# Altium schematic sheet LIDO-HW.100.FrontConnector.SchDoc(LIDO-HW.100.FrontConnector)
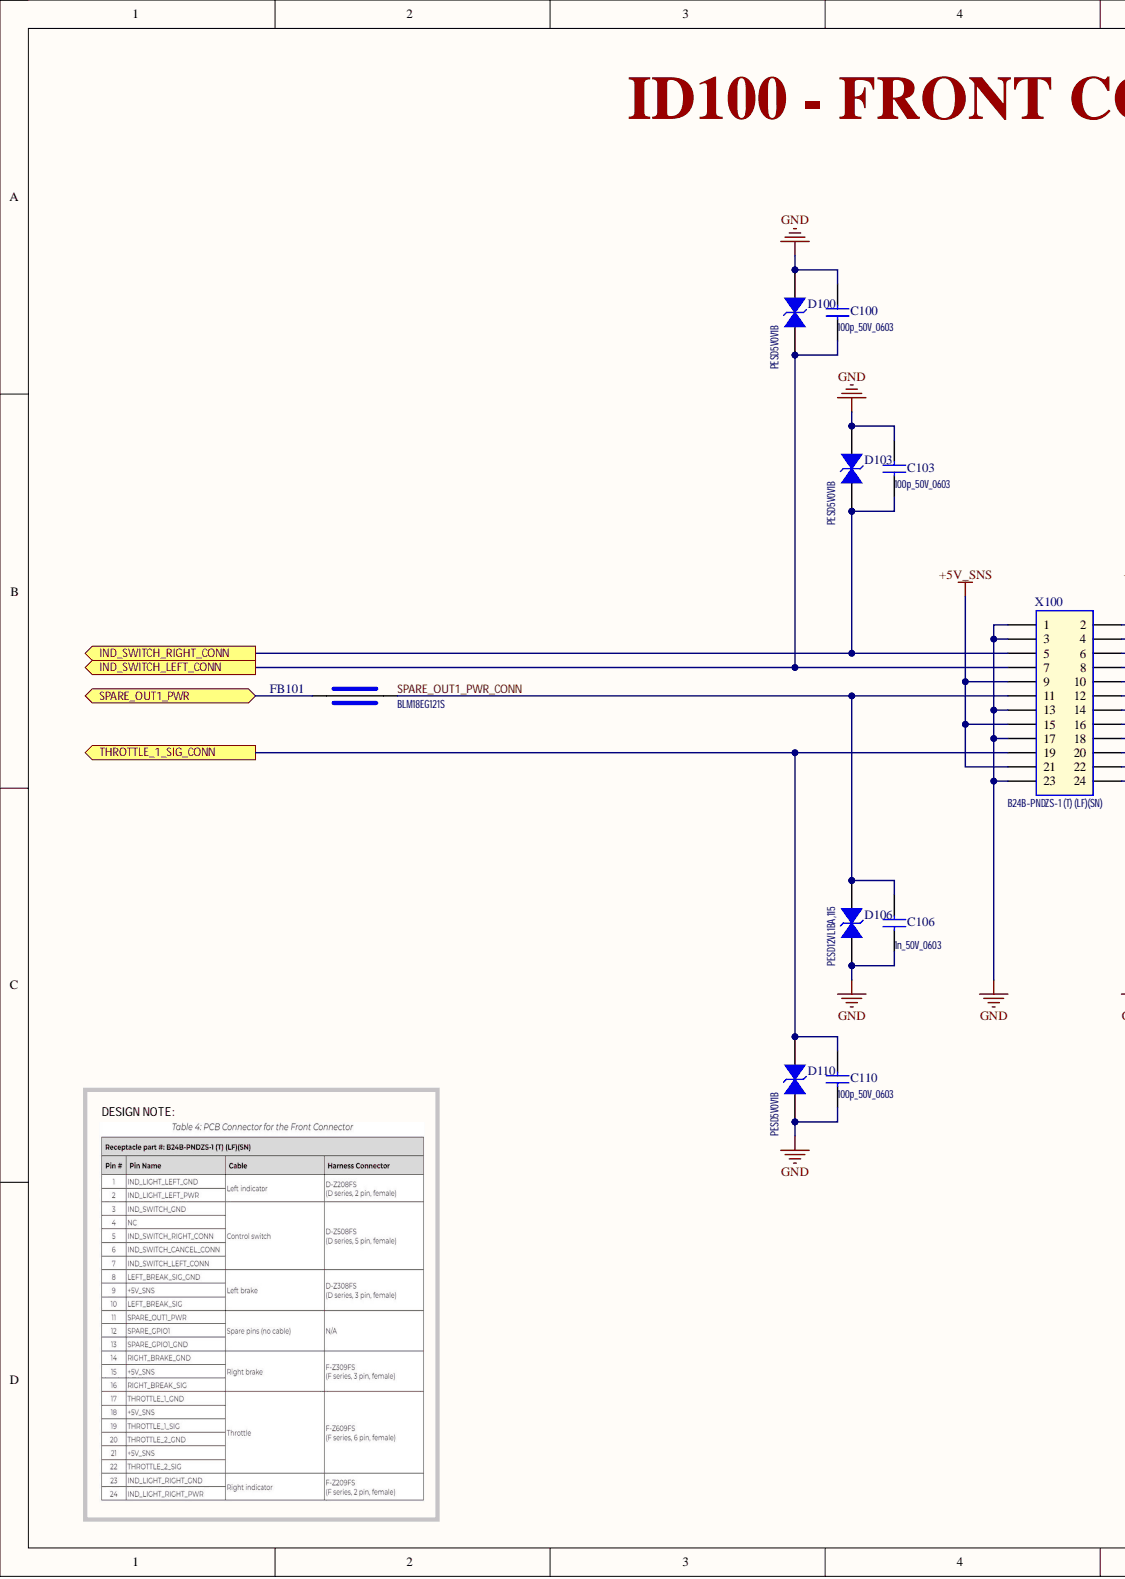
[diagram p0: LIDO-HW.100.FrontConnector.SchDoc, left]
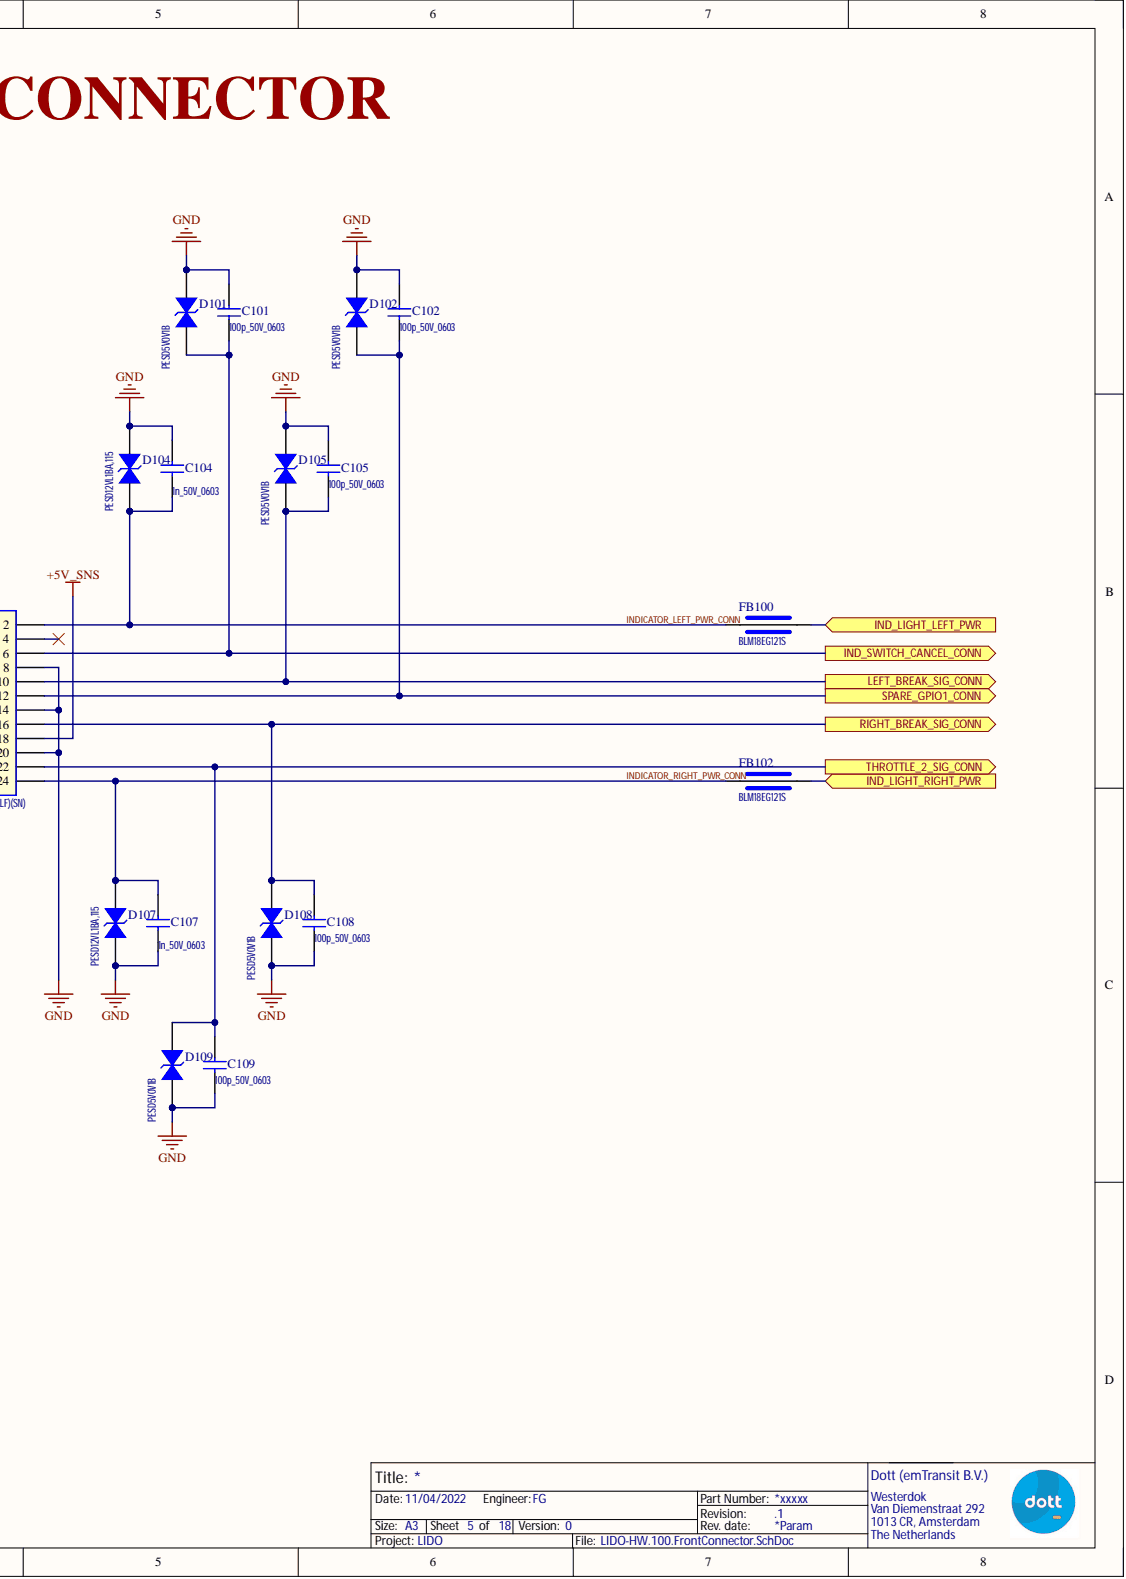
[diagram p1: LIDO-HW.100.FrontConnector.SchDoc, right]

## Parts
C100  100p_50V_0603
C101  100p_50V_0603
C102  100p_50V_0603
C103  100p_50V_0603
C104  1n_50V_0603
C105  100p_50V_0603
C106  1n_50V_0603
C107  1n_50V_0603
C108  100p_50V_0603
C109  100p_50V_0603
C110  100p_50V_0603
D100  PESD5V0V1B
D101  PESD5V0V1B
D102  PESD5V0V1B
D103  PESD5V0V1B
D104  PESD12VL1BA,115
D105  PESD5V0V1B
D106  PESD12VL1BA,115
D107  PESD12VL1BA,115
D108  PESD5V0V1B
D109  PESD5V0V1B
D110  PESD5V0V1B
FB100  BLM18EG121S
FB101  BLM18EG121S
FB102  BLM18EG121S
X100  B24B-PNDZS-1 (T) (LF)(SN)

## Ports
IND_LIGHT_LEFT_PWR, IND_LIGHT_RIGHT_PWR, IND_SWITCH_CANCEL_CONN, IND_SWITCH_LEFT_CONN, IND_SWITCH_RIGHT_CONN, LEFT_BREAK_SIG_CONN, RIGHT_BREAK_SIG_CONN, SPARE_GPIO1_CONN, SPARE_OUT1_PWR, THROTTLE_1_SIG_CONN, THROTTLE_2_SIG_CONN

## Nets
+5V_SNS: X100.9 X100.15 X100.18 X100.21
GND: C100.2 C101.2 C102.2 C103.2 C104.2 C105.2 C106.1 C107.1 C108.1 C109.1 C110.1 D100.C D101.C D102.C D103.C D104.C D105.C D106.A D107.A D108.A D109.A D110.A X100.1 X100.3 X100.8 X100.13 X100.14 X100.17 X100.20 X100.23
IND_LIGHT_LEFT_PWR: FB100.1 ; port IND_LIGHT_LEFT_PWR
IND_LIGHT_RIGHT_PWR: FB102.1 ; port IND_LIGHT_RIGHT_PWR
IND_SWITCH_CANCEL_CONN: C101.1 D101.A X100.6 ; port IND_SWITCH_CANCEL_CONN
IND_SWITCH_LEFT_CONN: C100.1 D100.A X100.7 ; port IND_SWITCH_LEFT_CONN
IND_SWITCH_RIGHT_CONN: C103.1 D103.A X100.5 ; port IND_SWITCH_RIGHT_CONN
INDICATOR_LEFT_PWR_CONN: C104.1 D104.A FB100.2 X100.2
INDICATOR_RIGHT_PWR_CONN: C107.2 D107.C FB102.2 X100.24
LEFT_BREAK_SIG_CONN: C105.1 D105.A X100.10 ; port LEFT_BREAK_SIG_CONN
RIGHT_BREAK_SIG_CONN: C108.2 D108.C X100.16 ; port RIGHT_BREAK_SIG_CONN
SPARE_GPIO1_CONN: C102.1 D102.A X100.12 ; port SPARE_GPIO1_CONN
SPARE_OUT1_PWR: FB101.1 ; port SPARE_OUT1_PWR
SPARE_OUT1_PWR_CONN: C106.2 D106.C FB101.2 X100.11
THROTTLE_1_SIG_CONN: C110.2 D110.C X100.19 ; port THROTTLE_1_SIG_CONN
THROTTLE_2_SIG_CONN: C109.2 D109.C X100.22 ; port THROTTLE_2_SIG_CONN

Not connected: X100 4

## Library data of the parts
C100-C110 = Ceramic: Ceramic CAP Symbol; Component Kind: Standard; Library Name: 01_Capacitors.SchLib
D100-D110 = BI-TVS_DIODE_B: Bidirectional TVS Diode Symbol; Component Kind: Standard; Library Name: 04_Circuit_Protection.SchLib
FB100-FB102 = FERRITE_BEAD: Ferrite Bead Symbol; Component Kind: Standard; Library Name: 08_Filters.SchLib
X100 = RECT_24PIN_2R_A: Rectengular connector, 24 pins, 2 row, Version A; Component Kind: Standard; Library Name: 20_Connectors_Rectangular.SchLib
